# Altium schematic sheet Microprocessor.SchDoc
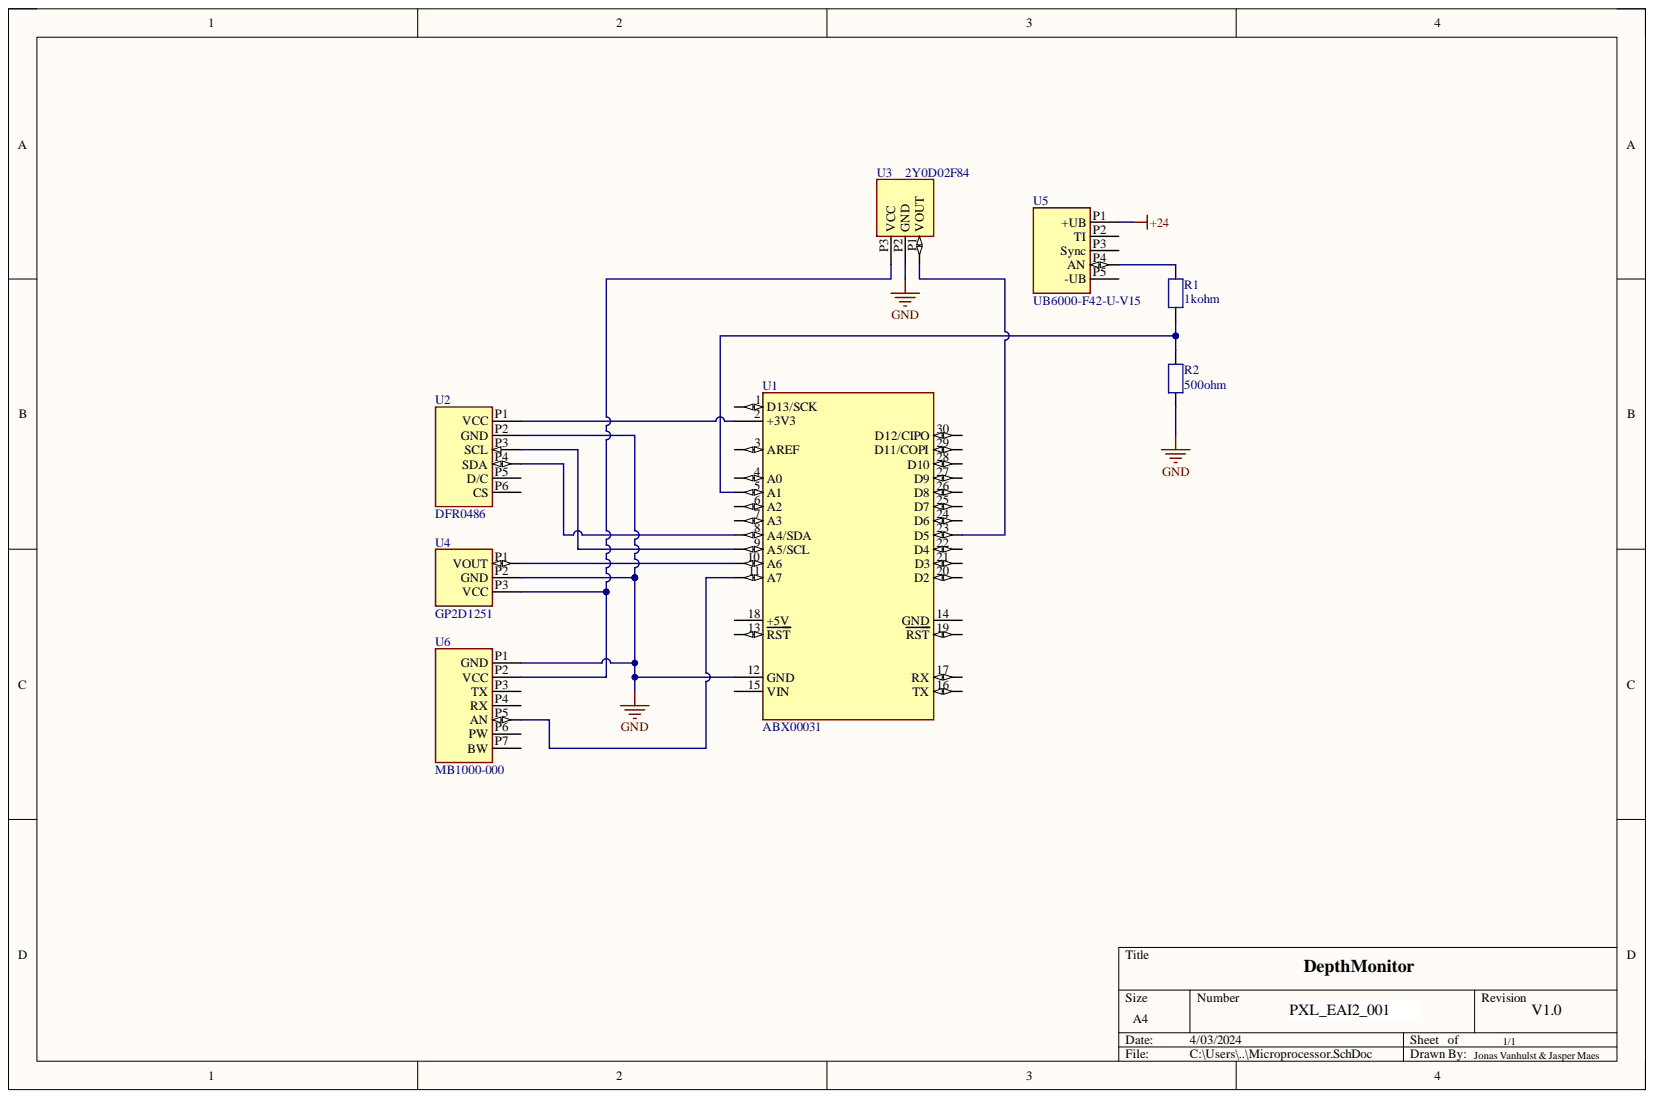
[diagram p0: Microprocessor.SchDoc]

## Parts
R1  1kohm  [Weerstand 1M ohm]
R2  500ohm  [Weerstand 1M ohm]
U1  ABX00031  [MODULE_ABX00030]
U2  DFR0486  [GP2DXX]
U3  2Y0D02F84  [GP2DXX]
U4  GP2D1251  [GP2DXX]
U5  UB6000-F42-U-V15  [GP2DXX]
U6  MB1000-000  [GP2DXX]

## Nets
+24: U5.P1(+UB)
GND: R2.2 U1.12(GND) U2.P2(GND) U3.P2 U4.P2 U6.P1(GND)
NetR1_1: R1.1 U5.P4(AN)
NetR1_2: R1.2 R2.1 U1.5(A1)
NetU1_2: U1.2(+3V3) U2.P1(VCC)
NetU1_8: U1.8(A4/SDA) U2.P4(SDA)
NetU1_9: U1.9(A5/SCL) U2.P3(SCL)
NetU1_10: U1.10(A6) U4.P1
NetU1_11: U1.11(A7) U6.P5(AN)
NetU1_23: U1.23(D5) U3.P1
NetU3_P3: U3.P3 U4.P3 U6.P2(VCC)

Not connected: U1 1(D13/SCK) 3(AREF) 4(A0) 6(A2) 7(A3) 13(RST) 14(GND) 15(VIN) 16(TX) 17(RX) 18(+5V) 19(RST) 20(D2) 21(D3) 22(D4) 24(D6) 25(D7) 26(D8) 27(D9) 28(D10) 29(D11/COPI) 30(D12/CIPO); U2 P5(D/C) P6(CS); U5 P2(TI) P3(Sync) P5(-UB); U6 P3(TX) P4(RX) P6(PW) P7(BW)

## Library data of the parts
R1 = Weerstand 1 kohm: Manufacturer: Vishay Dale; Part Number: CRCW0805100RFKEA; Component Kind: Standard; Library Name: arduino.SchLib
R2 = Weerstand 500 ohm: Manufacturer: Vishay Dale; Part Number: CRCW0805100RFKEA; Component Kind: Standard; Library Name: arduino.SchLib
U1 = ABX00031: Arduino Nano 33 BLE; MP: ABX00030; Availability: In Stock; Check_prices: https://www.snapeda.com/parts/ABX00030/Arduino/view-part/?ref=eda; Component Kind: Standard; Library Name: arduino.SchLib; MF: Arduino; Package: None; Price: None; Purchase-URL: https://pricing.snapeda.com/search/part/ABX00030/?ref=eda; SnapEDA_Link: https://www.snapeda.com/parts/ABX00030/Arduino/view-part/?ref=snap
U2 = DFR0486: 0.96" 128x64 OLED(V1.0) display DFROBOT; MF: Sharp; MP: GP2D120; Availability: Bad; Check_prices: https://www.snapeda.com/parts/GP2D120/Sharp%20Microelectronics/view-part/569732/?ref=eda; Component Kind: Standard; Library Name: arduino.SchLib; Package: None; Price: None; SnapEDA_Link: https://www.snapeda.com/parts/GP2D120/Sharp%20Microelectronics/view-part/569732/?ref=snap
U3 = 2Y0D02F84: SENSOR OPTICAL ANALOG; MF: Sharp; MP: GP2D120; Availability: Bad; Check_prices: https://www.snapeda.com/parts/GP2D120/Sharp%20Microelectronics/view-part/569732/?ref=eda; Component Kind: Standard; Library Name: arduino.SchLib; Package: None; Price: None; SnapEDA_Link: https://www.snapeda.com/parts/GP2D120/Sharp%20Microelectronics/view-part/569732/?ref=snap
U4 = GP2D1251: SENSOR OPTICAL ANALOG; MF: Sharp; MP: GP2D120; Availability: Bad; Check_prices: https://www.snapeda.com/parts/GP2D120/Sharp%20Microelectronics/view-part/569732/?ref=eda; Component Kind: Standard; Library Name: arduino.SchLib; Package: None; Price: None; SnapEDA_Link: https://www.snapeda.com/parts/GP2D120/Sharp%20Microelectronics/view-part/569732/?ref=snap
U5 = UB6000-F42-U-V15: Ultrasonic sensor; MF: Sharp; MP: GP2D120; Availability: Bad; Check_prices: https://www.snapeda.com/parts/GP2D120/Sharp%20Microelectronics/view-part/569732/?ref=eda; Component Kind: Standard; Library Name: arduino.SchLib; Package: None; Price: None; SnapEDA_Link: https://www.snapeda.com/parts/GP2D120/Sharp%20Microelectronics/view-part/569732/?ref=snap
U6 = MB1000-000: Analog ultrasonic sensor; MF: Sharp; MP: GP2D120; Availability: Bad; Check_prices: https://www.snapeda.com/parts/GP2D120/Sharp%20Microelectronics/view-part/569732/?ref=eda; Component Kind: Standard; Library Name: arduino.SchLib; Package: None; Price: None; SnapEDA_Link: https://www.snapeda.com/parts/GP2D120/Sharp%20Microelectronics/view-part/569732/?ref=snap
